# Altium schematic sheet Supply.SchDoc(Supply)
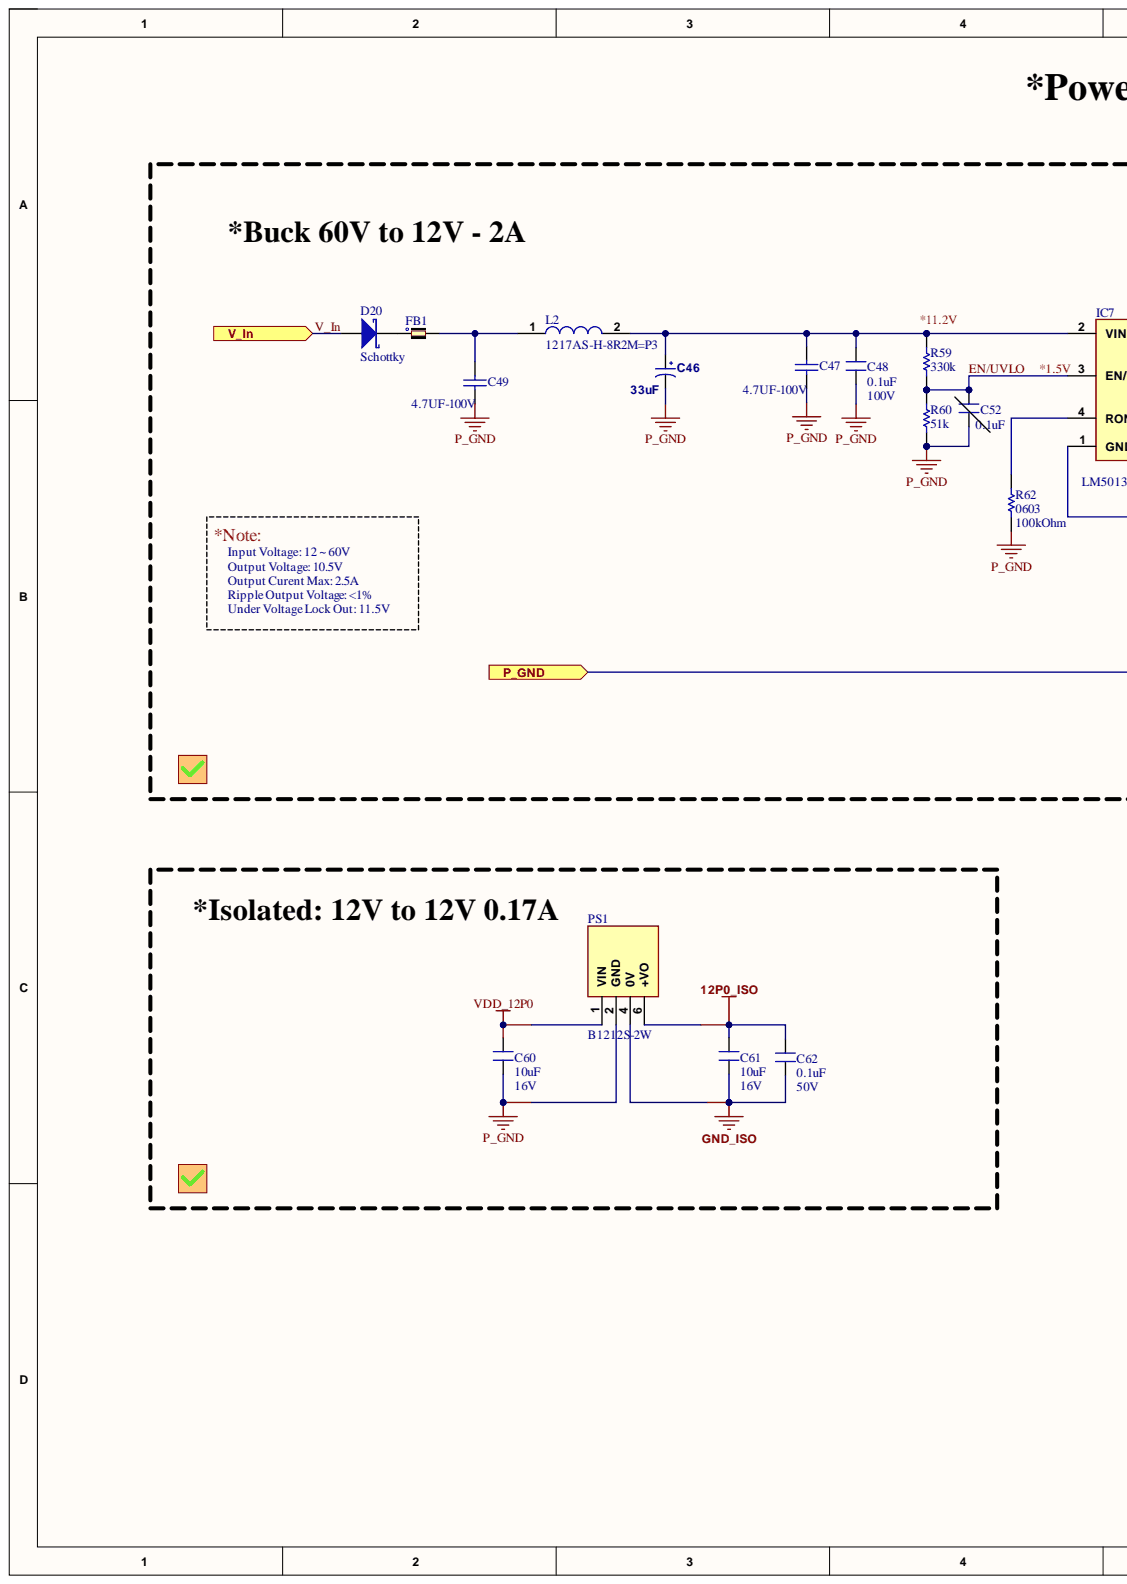
[diagram p0: Supply.SchDoc, left]
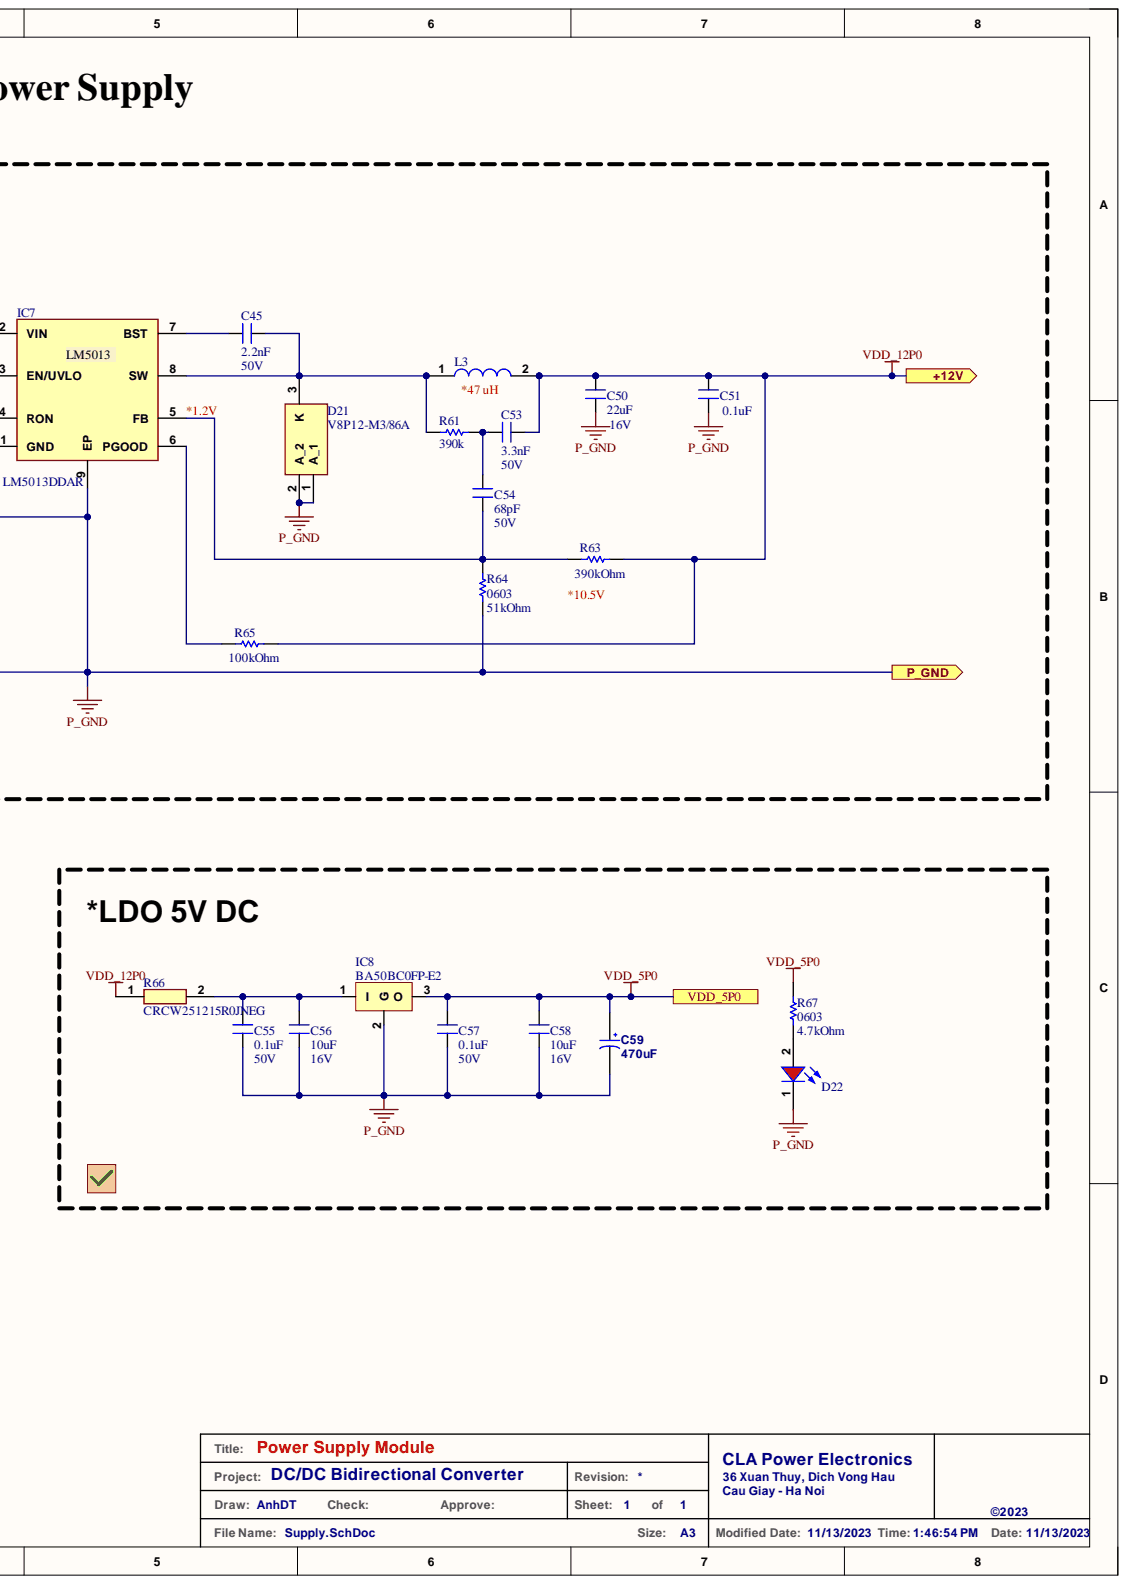
[diagram p1: Supply.SchDoc, right]

## Parts
C45  2.2nF  value 50V  [C_0603]
C46  value 33uF  [C_ALU_TH_8p0D11p5]  (hidden comment: Cap_Alu_TH_8P0D3P5_22uF)
C47  value 4.7UF-100V  [C_1210]  (hidden comment: GRM32ER71K475KE14L)
C48  0.1uF  value 100V  [C_0805]
C49  value 4.7UF-100V  [C_1210]  (hidden comment: GRM32ER71K475KE14L)
C50  22uF  value 16V  [C_1210]
C51  value 0.1uF  [C_0603]  (hidden comment: 0603)
C52  value 0.1uF  [C_0603]  (hidden comment: 0603)
C53  3.3nF  value 50V  [C_0603]
C54  68pF  value 50V  [C_0603]
C55  0.1uF  value 50V  [C_0603]
C56  10uF  value 16V  [C_0805]
C57  0.1uF  value 50V  [C_0603]
C58  10uF  value 16V  [C_0805]
C59  value 470uF  [C_ALU_TH_8p0D11p5]  (hidden comment: Cap_Alu_TH_8P0D3P5)
C60  10uF  value 16V  [C_0805]
C61  10uF  value 16V  [C_0805]
C62  0.1uF  value 50V  [C_0603]
D20  Schottky  [DIODE_Schottky_DO214]
D21  V8P12-M3/86A  [V8P12M386A]
D22  LED-SMD  [SM-LED-0805-Green]  (value not drawn)
FB1  FerriteBead_1206_270Ohm  [FERRITEBEAD_1206]  (value not drawn)
IC7  LM5013DDAR  [SOIC127P600X170-9N]
IC8  BA50BC0FP-E2  [TO252-123]
L2  1217AS-H-8R2M=P3  [1217ASH100MP3]
L3  (no value)  [Nonstandard]
PS1  B1212S-2W  [B1212S2W]
R59  330k  [R_0603]
R60  51k  [R_0603]
R61  390k  [R_0603]
R62  0603  value 100kOhm  [R_0603]
R63  value 390kOhm  [R_0603]  (hidden comment: 0603)
R64  0603  value 51kOhm  [R_0603]
R65  value 100kOhm  [R_0603]  (hidden comment: 0603)
R66  CRCW251215R0JNEG  [RESC6332X70N]
R67  0603  value 4.7kOhm  [R_0603]

## Ports
+12V, P_GND, V_In, VDD_5P0

## Nets
12P0_ISO: C61.2 C62.2 PS1.6(+VO)
GND_ISO: C61.1 C62.1 PS1.4(0V)
EN/UVLO: C52.1 IC7.3(EN/UVLO) R59.1 R60.2
P_GND: C46.2 C47.1 C48.1 C49.1 C50.1 C51.1 C52.2 C55.1 C56.1 C57.1 C58.1 C59.2 C60.1 D21.1 D21.2 D22.1 IC7.1(GND) IC7.9(EP) IC8.2 PS1.2(GND) R60.1 R62.1 R64.1 ; port P_GND
V_In: D20.1 ; port V_In
VDD_5P0: C57.2 C58.2 C59.1 IC8.3 R67.2 ; port VDD_5P0
VDD_12P0: C50.2 C51.2 C53.2 C60.2 L3.2 PS1.1(VIN) R63.2 R65.1 R66.1 ; port +12V
NetC45_1: C45.1 D21.3 IC7.8(SW) L3.1 R61.2
NetC45_2: C45.2 IC7.7(BST)
NetC46_1: C46.1 C47.2 C48.2 IC7.2(VIN) L2.2 R59.2
NetC49_2: C49.2 FB1.2 L2.1
NetC53_1: C53.1 C54.2 R61.1
NetC54_1: C54.1 IC7.5(FB) R63.1 R64.2
NetC55_2: C55.2 C56.2 IC8.1 R66.2
NetD20_2: D20.2 FB1.1
NetD22_2: D22.2 R67.1
NetIC7_4: IC7.4(RON) R62.2
NetIC7_6: IC7.6(PGOOD) R65.2

## Library data of the parts
C45 = Cap_Cer_0805_10pF: CAP CER 2.2nF 50V X7R 0805; Manufacturer: Samsung Electro-Mechanics; Part Code: S1200-000116; Part Number: C0805C222J5GEC7800; Description SEL: CAP CER 2.2nF 50V X7R 0603; Point: 2; Component Kind: Standard; Footprint Type: SMD; Library Name: S1200_Capacitor.SchLib
C46 = S1200-000209: CAP ALUM 33UF 20% 80V RADIAL; Manufacturer: PANASONIC; Part Number: 80ZLH33MEFC6.3X11; Database Table Name: S1200_Capacitor$; Point: 2; Component Kind: Standard; Description SEL: CAP ALUM 33UF 20% 80V RADIAL; Footprint Type: TH; Part Code: S1200-000209; Library Name: Selex_Component_Lib.SVNDbLib
C47 C49 = Cap 1210: CAP CER 4.7uF 80V X7R 1210; Manufacturer: TDK Corporation; Description std: CAP CER 4.7UF 100V X7S 1210; Category: Capacitors; Component Kind: Standard; Digikey Price/1KU: 0.56250; Digikey Price/1unit: 1.64; Library Name: DC_DC_Power_V3.0.IntLib; Link: https://www.digikey.com/product-detail/en/tdk-corporation/C3225X7S2A475M200AB/445-6043-2-ND/2443521; Package: 1210; Part Number: GRM32ER71K475KE14L
C48 = Cap_Cer_0805_10pF: CAP CER 0.1uF 100V X7R 0805; Manufacturer: Samsung Electro-Mechanics; Part Code: S1200-000116; Point: 2; Component Kind: Standard; Footprint Type: SMD; Library Name: S1200_Capacitor.SchLib
C50 = Cap_Cer_0805_10pF: CAP CER 22uF 16V X7R 1210; Manufacturer: Samsung Electro-Mechanics; Part Code: S1200-000116; Part Number: CL32A226KOJNNNE; Point: 2; Component Kind: Standard; Description SEL: CAP CER 22uF 16V X7R 1210; Footprint Type: SMD; Library Name: S1200_Capacitor.SchLib
C51 = Cap_Cer_0603_0.1uF_25V: CAP CER 0.1UF 25V X8R 0603; Manufacturer: Murata Electronics; Part Code: S1200-000077; Part Number: GCM188R91E104KA37J; Point: 2; Component Kind: Standard; Description SEL: CAP CER 0.1UF 25V X8R 0603; Footprint Type: SMD; Library Name: S1200_Capacitor.SchLib
C52 = Cap_Cer_0603_0.1uF_100V: CAP CER 0.1UF 100V X7R 0603; Manufacturer: Murata Electronics; Part Code: S1200-000079; Part Number: GRM188R72A104KA35D; Point: 2; Component Kind: Standard; Description SEL: CAP CER 0.1UF 100V X7R 0603; Footprint Type: SMD; Library Name: S1200_Capacitor.SchLib
C53 = Cap_Cer_0805_10pF: CAP CER 0805 3.3NF 50V C0G 1%; Manufacturer: Kemet; Part Code: S1200-000116; Part Number: C0805C332F5GECAUTO; Description SEL: 10pF 50VDC; Point: 2; Component Kind: Standard; Footprint Type: SMD; Library Name: S1200_Capacitor.SchLib; Value: 50V
C54 = Cap_Cer_0805_10pF: CAP CER 68pF 50V C0G 0603; Manufacturer: Kemet; Part Code: S1200-000116; Part Number: CL10C680JB81PNC; Point: 2; Component Kind: Standard; Description SEL: CAP CER 68pF 50V C0G 0603; Footprint Type: SMD; Library Name: S1200_Capacitor.SchLib
C55 C57 C62 = Cap_Cer_0805_10pF: CAP CER 0.1uF 50V X7R 0603; Manufacturer: Kemet; Part Code: S1200-000116; Part Number: CL10C680JB81PNC; Description SEL: CAP CER 68pF 50V C0G 0603; Point: 2; Component Kind: Standard; Footprint Type: SMD; Library Name: S1200_Capacitor.SchLib
C56 C58 = Cap_Cer_0805_10pF: CAP CER 10uF 16V X7R 0805; Manufacturer: Kemet; Part Code: S1200-000116; Part Number: CL21A106KOCLRNC; Point: 2; Component Kind: Standard; Description SEL: CAP CER 10uF 16V X7R 0805; Footprint Type: SMD; Library Name: S1200_Capacitor.SchLib
C59 = S1200-000039: CAP ALUM 470UF 20% 25V RADIAL; Manufacturer: Rubycon; Part Number: 16YXJ220MT16.3X11; Database Table Name: S1200_Capacitor$; Point: 2; Component Kind: Standard; Description SEL: CAP ALUM 470UF 20% 25V RADIAL; Footprint Type: TH; Part Code: S1200-000039; Library Name: Selex_Component_Lib.SVNDbLib
C60 = Cap_Cer_0805_10pF: CAP CER 2.2uF 50V X7R 0805; Manufacturer: Samsung Electro-Mechanics; Part Code: S1200-000116; Part Number: CL31B225KBHNNNE; Description SEL: 10pF 50VDC; Point: 2; Component Kind: Standard; Footprint Type: SMD; Library Name: S1200_Capacitor.SchLib
C61 = Cap_Cer_0805_10pF: CAP CER 4.7uF 50V X7R 0805; Manufacturer: Samsung Electro-Mechanics; Part Code: S1200-000116; Part Number: CL31B225KBHNNNE; Description SEL: 10pF 50VDC; Point: 2; Component Kind: Standard; Footprint Type: SMD; Library Name: S1200_Capacitor.SchLib
D20 = SS34: DIODE SCHOTTKY 100V 3A SMA; Manufacturer: SMC Diode Solutions; Part Code: S1500-000030; Part Number: SS310; Point: 2; Value: 3A 100V; Component Kind: Standard; Description SEL: DIODE SCHOTTKY 100V 3A SMA; Footprint Type: SMA; Library Name: S1500_Diode.SchLib; Packaging: DIODE_Schottky_DO214
D21 = V8P12-M3_86A: DIODE SCHOTTKY 120V 8A TO277A; Manufacturer_Name: Vishay; Arrow Part Number: V8P12-M3/86A; Arrow Price/Stock: https://www.arrow.com/en/products/v8p12-m386a/vishay?region=nac; Component Kind: Standard; Datasheet Link: https://www.vishay.com/docs/89170/v8p12.pdf; Height: 1.2mm; Mouser Part Number: 78-V8P12-M3/86A; Mouser Price/Stock: https://www.mouser.co.uk/ProductDetail/Vishay-General-Semiconductor/V8P12-M3-86A?qs=HSPD0Bff7iYR%2FGS73JOLOg%3D%3D; Part Number: V8P12-M3/86A
D22 = LED-SMD: LED GREEN DIFFUSED 0805 SMD; Manufacturer: OSRAM Opto Semiconductors Inc.; Category: LED; Component Kind: Standard; Footprint type: SMD; Library Name: Motor Control SELEX MOTORS JSC.SchLib
FB1 = FerriteBead_1206_270Ohm: FERRITE BEAD 270 OHM 1206 1LN; Manufacturer: Murata Electronics; Part Code: S1100-000016; Part Number: BLM31KN271SH1L; Point: 2; Value: 270Ohm; Component Kind: Standard; Description SEL: FERRITE BEAD 270 OHM 1206 1LN; Footprint Type: SMD; Library Name: S1100_Resistor_FerriteBead.SchLib
IC7 = LM5012DDAR: IC REG BUCK ADJ 3.5A 8SO PWR; Component Kind: Standard; Part Number: LM5013DDAR; Library Name: Tienanh.SchLib
IC8 = BA50BC0FP-E2: IC REG LINEAR 5V 1A TO252-2; Manufacture: Rohm Semiconductor; Category: IC; Component Kind: Standard; ComponentLink1Description: Manufacturer Link; ComponentLink1URL: http://www.st.com/; ComponentLink2Description: Datasheet; ComponentLink2URL: http://www.st.com/stonline/products/literature/ds/2146/l78m05c.pdf; Footprint type: SMD; Part Number: BA50BC0FP-E2; Library Name: Motor Control SELEX MOTORS JSC.SchLib
L2 = 1217AS-H-8R2M=P3: Inductor 8.2 uH; Manufacturer_Name: Murata Electronics; Arrow Part Number: 1217AS-H-8R2M=P3; Arrow Price/Stock: https://www.arrow.com/en/products/1217as-h-8r2mp3/murata-manufacturing; Component Kind: Standard; Datasheet Link: https://psearch.en.murata.com/inductor/product/1217AS-H-8R2M%23.html; Height: 4.5mm; Mouser Part Number: 81-1217AS-H-8R2MP3; Mouser Price/Stock: https://www.mouser.co.uk/ProductDetail/Murata-Electronics/1217AS-H-8R2M%3dP3?qs=4tuKoHGzn116ThiZXqrSgw%3D%3D; Part Number: 1217AS-H-8R2M=P3
L3 = Inductor: FIXED IND 47UH 2.5A 100 MOHM SMD; Manufacturer: Taiyo Yuden; Part Number: CDRH127-470; Category: Inductor; Component Kind: Standard; Footprint type: SMD; Library Name: Motor Control SELEX MOTORS JSC.SchLib
PS1 = B1212S-2W: Power Supply; Manufacturer_Name: Mornsun Power; Component Kind: Standard; Datasheet Link: https://www.mornsun-power.com/public/uploads/pdf/B_S-2WR3.pdf; Height: 10.41mm; Manufacturer_Part_Number: B1212S-2W
R59 = Resistor_0603_10Ohm 1%: RES 200K OHM 1% 1/10W 0603; Manufacturer: YAGEO; Part Code: S1100-000044; Part Number: RC0603FR-13200KL; Point: 2; Power: 1/8W; Component Kind: Standard; Description SEL: RES 200K OHM 1% 1/10W 0603; Footprint Type: SMD; Library Name: S1100_Resistor_FerriteBead.SchLib; Tolerance: 1%
R60 = Resistor_0603_10Ohm 1%: RES 24k OHM 1% 1/8W 0603; Manufacturer: YAGEO; Part Code: S1100-000044; Part Number: RC0603FR-1024KL; Description SEL: RES 24K OHM 1% 1/10W 0603; Point: 2; Value: 8.2-10kOhm; Component Kind: Standard; Footprint Type: SMD; Library Name: S1100_Resistor_FerriteBead.SchLib; Power: 1/8W; Tolerance: 1%
R61 = Resistor_0603_10Ohm 1%: RES 390k OHM 1% 1/8W 0603; Manufacturer: YAGEO; Part Code: S1100-000044; Part Number: RC0603FR-07390KL; Description SEL: RES 390K OHM 1% 1/10W 0603; Point: 2; Component Kind: Standard; Footprint Type: SMD; Library Name: S1100_Resistor_FerriteBead.SchLib; Power: 1/8W; Tolerance: 1%
R62 R65 = Resistor_0603_100kOhm: RES SMD 100K OHM 1% 1/8W 0603; Manufacturer: Vishay Beyschlag/Draloric/BC Components; Part Code: S1100-000051; Part Number: MCT06030C1003FP500; Point: 2; Component Kind: Standard; Description SEL: RES SMD 100K OHM 1% 1/8W 0603; Footprint Type: SMD; Library Name: S1100_Resistor_FerriteBead.SchLib; Power: 1/8W; Tolerance: 1%
R63 = Resistor_0603_470kOhm: RES SMD 470K OHM 1% 1/10W 0603; Manufacturer: Walsin Technology Corporation; Part Code: S1100-000056; Point: 2; Component Kind: Standard; Description SEL: RES SMD 470K OHM 1% 1/10W 0603; Footprint Type: SMD; Library Name: S1100_Resistor_FerriteBead.SchLib; Power: 1/10W; Tolerance: 1%
R64 = Resistor_0603_51kOhm: RES SMD 51K OHM 1% 1/8W 0603; Manufacturer: YAGEO; Part Code: S1100-000148; Part Number: RC0603FR-0751KL; Point: 2; Component Kind: Standard; Description SEL: RES SMD 51K OHM 1% 1/8W 0603; Footprint Type: SMD; Library Name: S1100_Resistor_FerriteBead.SchLib; Power: 1/8W; Tolerance: 1%
R66 = CRCW251215R0JNEG: Manufacturer_Name: Vishay; Arrow Part Number: CRCW251215R0JNEG; Arrow Price/Stock: https://www.arrow.com/en/products/crcw251215r0jneg/vishay; Component Kind: Standard; Datasheet Link: http://www.vishay.com/docs/20035/dcrcwe3.pdf; Description: CRCW251215R0JNEG; Height: 0.7mm; Mouser Part Number: 71-CRCW2512J-15-E3; Mouser Price/Stock: https://www.mouser.co.uk/ProductDetail/Vishay-Dale/CRCW251215R0JNEG?qs=Z1AZHWO%2FsqLBwgjNhUTIZA%3D%3D; Part Number: CRCW251215R0JNEG
R67 = Resistor_0603_4.7kOhm: RES 2K OHM 1% 1/10W 0603; Manufacturer: YAGEO; Part Code: S1100-000158; Part Number: RC0603FR-074K7L; Description SEL: RES 4.7K OHM 1% 1/10W 0603; Point: 2; Component Kind: Standard; Footprint Type: SMD; Library Name: S1100_Resistor_FerriteBead.SchLib; Power: 1/10W; Tolerance: 1%
